# Altium schematic sheet CN032-1 Industry EtherCAT Servo Controller_PMIC.SchDoc
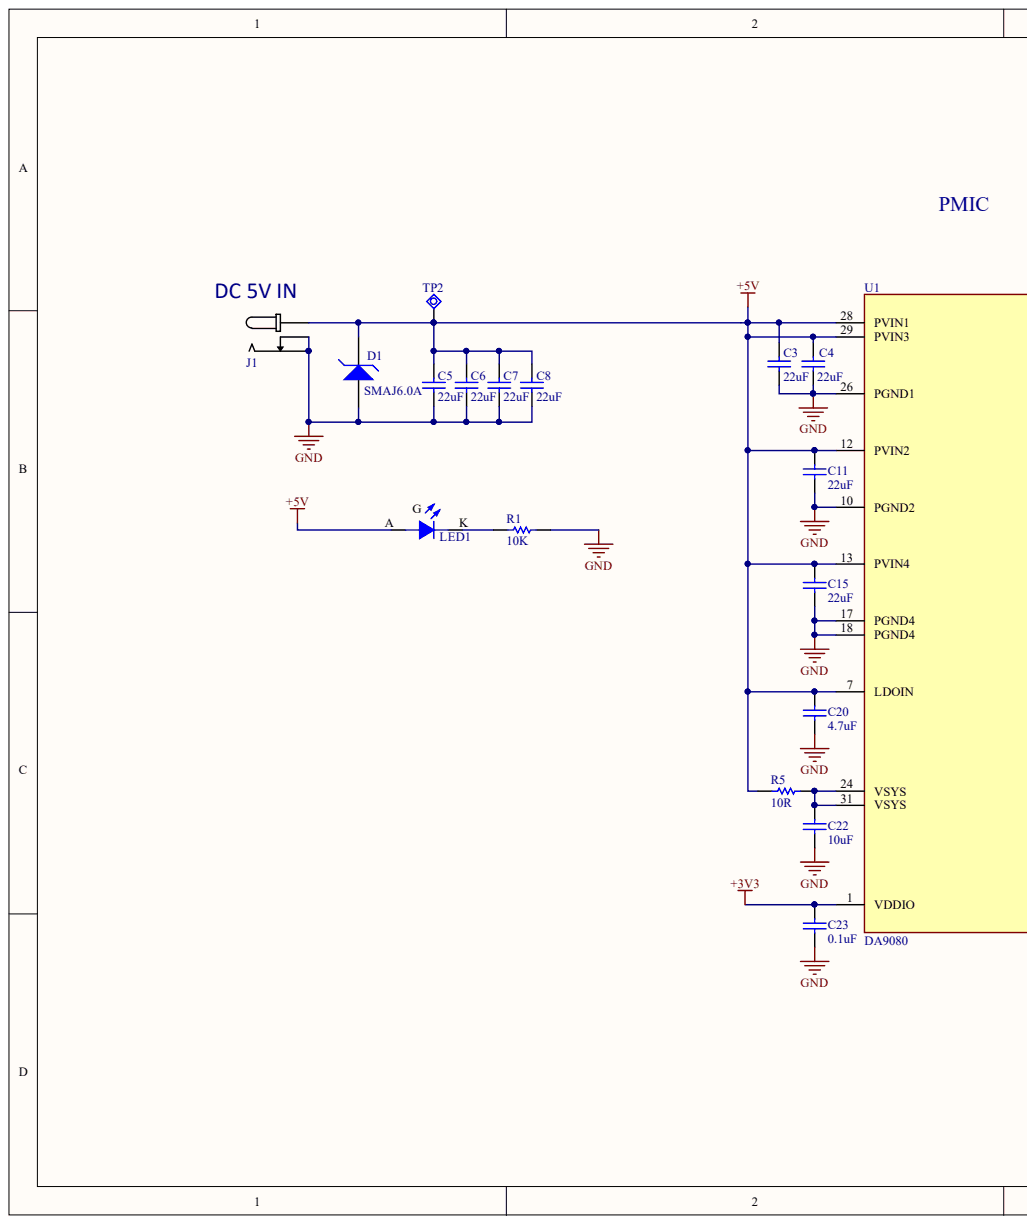
[diagram p0: CN032-1 Industry EtherCAT Servo Controller_PMIC.SchDoc, left]
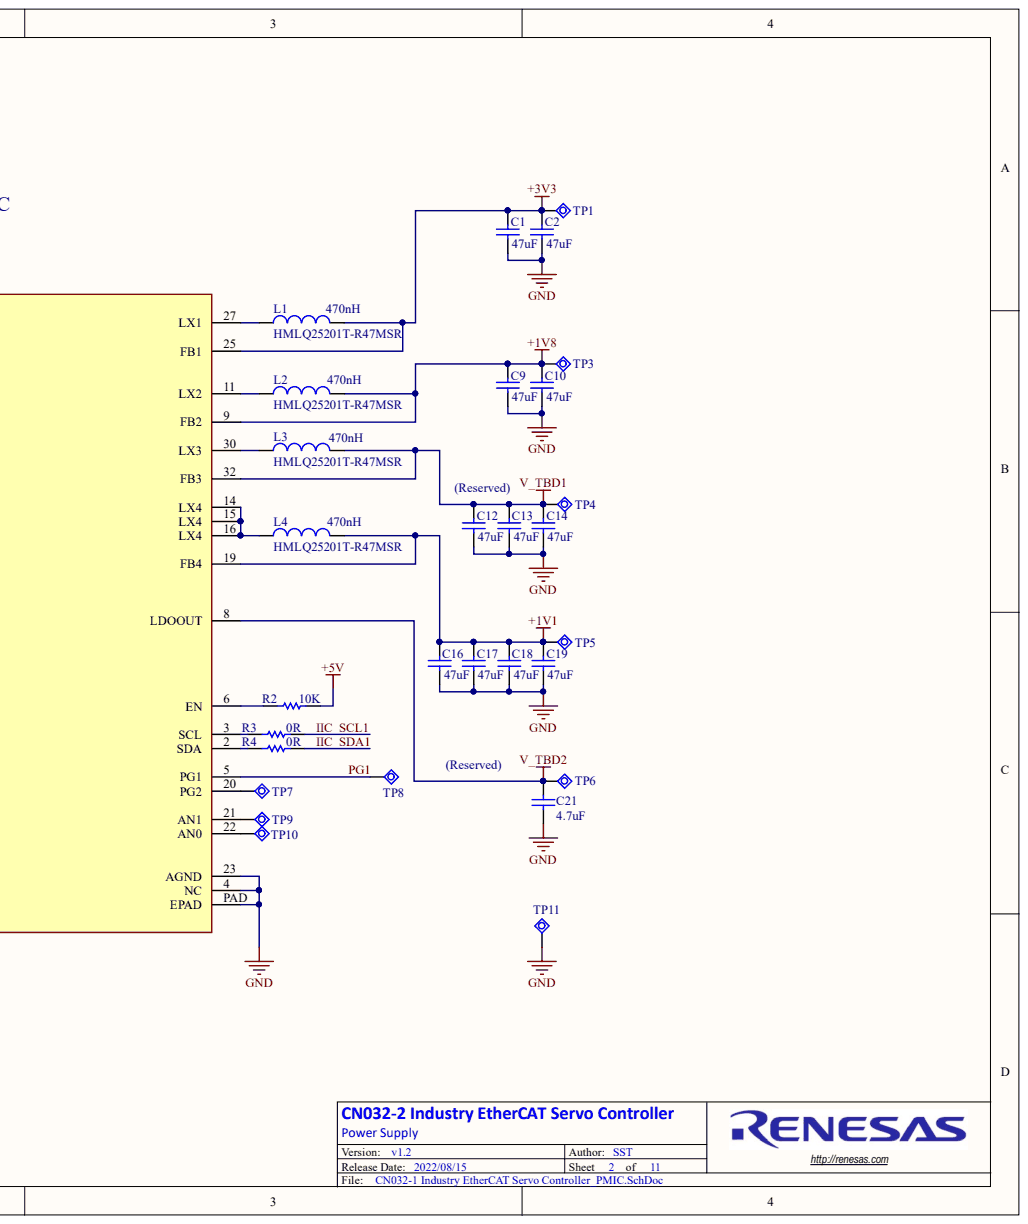
[diagram p1: CN032-1 Industry EtherCAT Servo Controller_PMIC.SchDoc, right]

## Parts
C1  47uF  [CAPC0603-01]
C2  47uF  [CAPC0603-01]
C3  22uF  [CAPC0603-01]
C4  22uF  [CAPC0603-01]
C5  22uF  [CAPC0805-01]
C6  22uF  [CAPC0805-01]
C7  22uF  [CAPC0805-01]
C8  22uF  [CAPC0805-01]
C9  47uF  [CAPC0603-01]
C10  47uF  [CAPC0603-01]
C11  22uF  [CAPC0603-01]
C12  47uF  [CAPC0603-01]
C13  47uF  [CAPC0603-01]
C14  47uF  [CAPC0603-01]
C15  22uF  [CAPC0603-01]
C16  47uF  [CAPC0603-01]
C17  47uF  [CAPC0603-01]
C18  47uF  [CAPC0603-01]
C19  47uF  [CAPC0603-01]
C20  4.7uF  [CAPC0402-01]
C21  4.7uF  [CAPC0402-01]
C22  10uF  [CAPC0402-01]
C23  0.1uF  [CAPC0402-01]
D1  SMAJ6.0A  [DO-214AC SMA]
J1  DC Jack  [KOBICON_163-7620E]  (value not drawn)
L1  470nH  Mfg Part Number: HMLQ25201T-R47MSR  [L1008 (2520)]
L2  470nH  Mfg Part Number: HMLQ25201T-R47MSR  [L1008 (2520)]
L3  470nH  Mfg Part Number: HMLQ25201T-R47MSR  [L1008 (2520)]
L4  470nH  Mfg Part Number: HMLQ25201T-R47MSR  [L1008 (2520)]
LED1  LED Green  [LED_0603-GRN]  (value not drawn)
R1  10K  [RESC0402-01]
R2  10K  [RESC0402-01]
R3  0R  [RESC0402-01]
R4  0R  [RESC0402-01]
R5  10R  [RESC0402-01]
TP1  Test Point  [TEST POINT]  (value not drawn)
TP2  Test Point  [TEST POINT]  (value not drawn)
TP3  Test Point  [TEST POINT]  (value not drawn)
TP4  Test Point  [TEST POINT]  (value not drawn)
TP5  Test Point  [TEST POINT]  (value not drawn)
TP6  Test Point  [TEST POINT]  (value not drawn)
TP7  Test Point  [TEST POINT]  (value not drawn)
TP8  Test Point  [TEST POINT]  (value not drawn)
TP9  Test Point  [TEST POINT]  (value not drawn)
TP10  Test Point  [TEST POINT]  (value not drawn)
TP11  Test Point  [TEST POINT]  (value not drawn)
U1  DA9080  [DA9080]

## Nets
+1V1: C16.2 C17.2 C18.2 C19.2 L4.2 TP5.1 U1.19(FB4)
+1V8: C9.2 C10.2 L2.2 TP3.1 U1.9(FB2)
+3V3: C1.2 C2.2 C23.2 L1.2 TP1.1 U1.1(VDDIO) U1.25(FB1)
+5V: C3.2 C4.2 C5.2 C6.2 C7.2 C8.2 C11.2 C15.2 C20.1 D1.K J1.TIP LED1.A R2.2 R5.1 TP2.1 U1.7(LDOIN) U1.12(PVIN2) U1.13(PVIN4) U1.28(PVIN1) U1.29(PVIN3)
GND: C1.1 C2.1 C3.1 C4.1 C5.1 C6.1 C7.1 C8.1 C9.1 C10.1 C11.1 C12.1 C13.1 C14.1 C15.1 C16.1 C17.1 C18.1 C19.1 C20.2 C21.1 C22.1 C23.1 D1.A J1.SH J1.SL R1.1 TP11.1 U1.4(NC) U1.10(PGND2) U1.17(PGND4) U1.18(PGND4) U1.23(AGND) U1.26(PGND1) U1.PAD(EPAD)
V_TBD1: C12.2 C13.2 C14.2 L3.2 TP4.1 U1.32(FB3)
V_TBD2: C21.2 TP6.1 U1.8(LDOOUT)
IIC_SCL1: R3.2
IIC_SDA1: R4.2
PG1: TP8.1 U1.5(PG1)
NetC22_2: C22.2 R5.2 U1.24(VSYS) U1.31(VSYS)
NetL1_1: L1.1 U1.27(LX1)
NetL2_1: L2.1 U1.11(LX2)
NetL3_1: L3.1 U1.30(LX3)
NetL4_1: L4.1 U1.14(LX4) U1.15(LX4) U1.16(LX4)
NetLED1_K: LED1.K R1.2
NetR2_1: R2.1 U1.6(EN)
NetR3_1: R3.1 U1.3(SCL)
NetR4_1: R4.1 U1.2(SDA)
NetTP7_1: TP7.1 U1.20(PG2)
NetTP9_1: TP9.1 U1.21(AN1)
NetTP10_1: TP10.1 U1.22(AN0)

## Library data of the parts
C1 C2 C9 C10 C12-C14 C16-C19 = CAP-0603-47uF: Ceramic Chip Capacitor 0603 47uF 6.3V; Manufacturer: Murata; Mfg Part Number: GRM188R60J476ME15D; Dialectric: X5R; Tolerance: 20%; Capacitance: 47uF; Component Kind: Standard; Package: 0603; Voltage: 6.3V; Library Name: Synergy.IntLib
C3 C4 C11 C15 = CAP-0603-22uF: Ceramic Chip Capacitor 0603 22uF 10V; Manufacturer: YAGEO; Mfg Part Number: CC0603MRX5R6BB226; Dialectric: X5R; Tolerance: 20%; Capacitance: 22uF; Component Kind: Standard; Package: 0603; Voltage: 10V; Library Name: Synergy.SchLib
C5-C8 = CAP-0805-22uF-35V: Ceramic Chip Capacitor 0805 22uF 35V; Manufacturer: YAGEO; Mfg Part Number: C2012JB1V226M125AC; Dialectric: X5R; Tolerance: 20%; Capacitance: 22uF; Component Kind: Standard; Package: 0805; Voltage: 35V; Library Name: Synergy.SchLib
C20 C21 = CAP-0402-4.7uF-YAGEO: Ceramic Chip Capacitor 0402 4.7uF 6.3V; Manufacturer: YAGEO; Mfg Part Number: CC0402MRX5R5BB475; Dialectric: X5R; Tolerance: 20%; Capacitance: 4.7uF; Component Kind: Standard; Package: 0402; Voltage: 6.3V; Library Name: Synergy.IntLib
C22 = CAP-0402-10uF: Ceramic Chip Capacitor 0402 10uF 6.3V; Manufacturer: YAGEO; Mfg Part Number: CC0402MRX5R5BB106; Tolerance: 20%; Capacitance: 10uF; Component Kind: Standard; Package: 0402; Voltage: 6.3V; Library Name: Synergy.IntLib
C23 = CAP-0402-0.1uF-YAGEO: Ceramic Chip Capacitor 0402 0.1uF 16V; Manufacturer: YAGEO; Mfg Part Number: CC0402KPX7R7BB104; Dialectric: X7R; Tolerance: 10%; Capacitance: 0.1uF; Component Kind: Standard; Package: 0402; Voltage: 16V; Library Name: Synergy.IntLib
D1 = TVS-SMAJ6.0A: TVS DIODE 6VWM 10.3VC DO214AC; Manufacturer: Littelfuse Inc.; Component Kind: Standard; Display Name: SMAJ6.0A; Mfg Part Number: SMAJ6.0A; Package: DO214AC; Library Name: Synergy.IntLib
J1 = CON-2.0mm DC Jack: Kobicon DC Jack 2.0mm TH; Manufacturer: Kobiconn; Mfg Part Number: 163-7620E-E; Component Kind: Standard; Library Name: Synergy.SchLib
L1-L4 = IND-470nH-4.3A: FIXED IND 470NH 4.3A 25MOHM SMD; Manufacturer: Delta Electronics/Cyntec; Component Kind: Standard; Current: 4.3A; Inductance: 470nH; Size: 1008（2520 公制）; Library Name: Synergy.IntLib
LED1 = LED-0603-Green: Lite-On LED Green Clear 0603; Mfg Part Number: LTST-C191KGKT; Serious P/N: LED-000004-01; Component Kind: Standard; Manufacturer: Lite-On; Package: 0603; Library Name: Synergy.SchLib
R1 R2 = RES-0402-10K: Chip Resistor Thick Film 0402 10K 1% 1/16W; Manufacturer: YAGEO; Mfg Part Number: RC0402FR-0710KL; Component Kind: Standard; Package: 0402; Power: 1/16W; Resistance: 10K; Tolerance: 1%; Library Name: Synergy.SchLib
R3 R4 = RES-0402-0R: Chip Resistor Thick Film 0402 0R 1% 1/16W; Manufacturer: YAGEO; Mfg Part Number: RC0402JR-070RL; Component Kind: Standard; Package: 0402; Power: 1/16W; Resistance: 0R; Tolerance: 1%; Library Name: Synergy.IntLib
R5 = RES-0402-10R: Chip Resistor Thick Film 0402 10R 1% 1/16W; Manufacturer: YAGEO; Mfg Part Number: RC0402JR-0710RL; Tolerance: 5%; Component Kind: Standard; Package: 0402; Power: 1/16W; Resistance: 10R; Library Name: Synergy.IntLib
TP1-TP11 = CON-Test Point: Component Kind: Standard; Description: Test Point; Library Name: Synergy.SchLib
U1 = ICN-DA9080: PMIC with Four Bucks and One LDO; Manufacturer: Renesas; Component Kind: Standard; Display Name: DA9080; Mfg Part Number: DA9080; Library Name: Synergy.IntLib
